# Altium schematic sheet Controller R2.SchDoc("Controller R2")
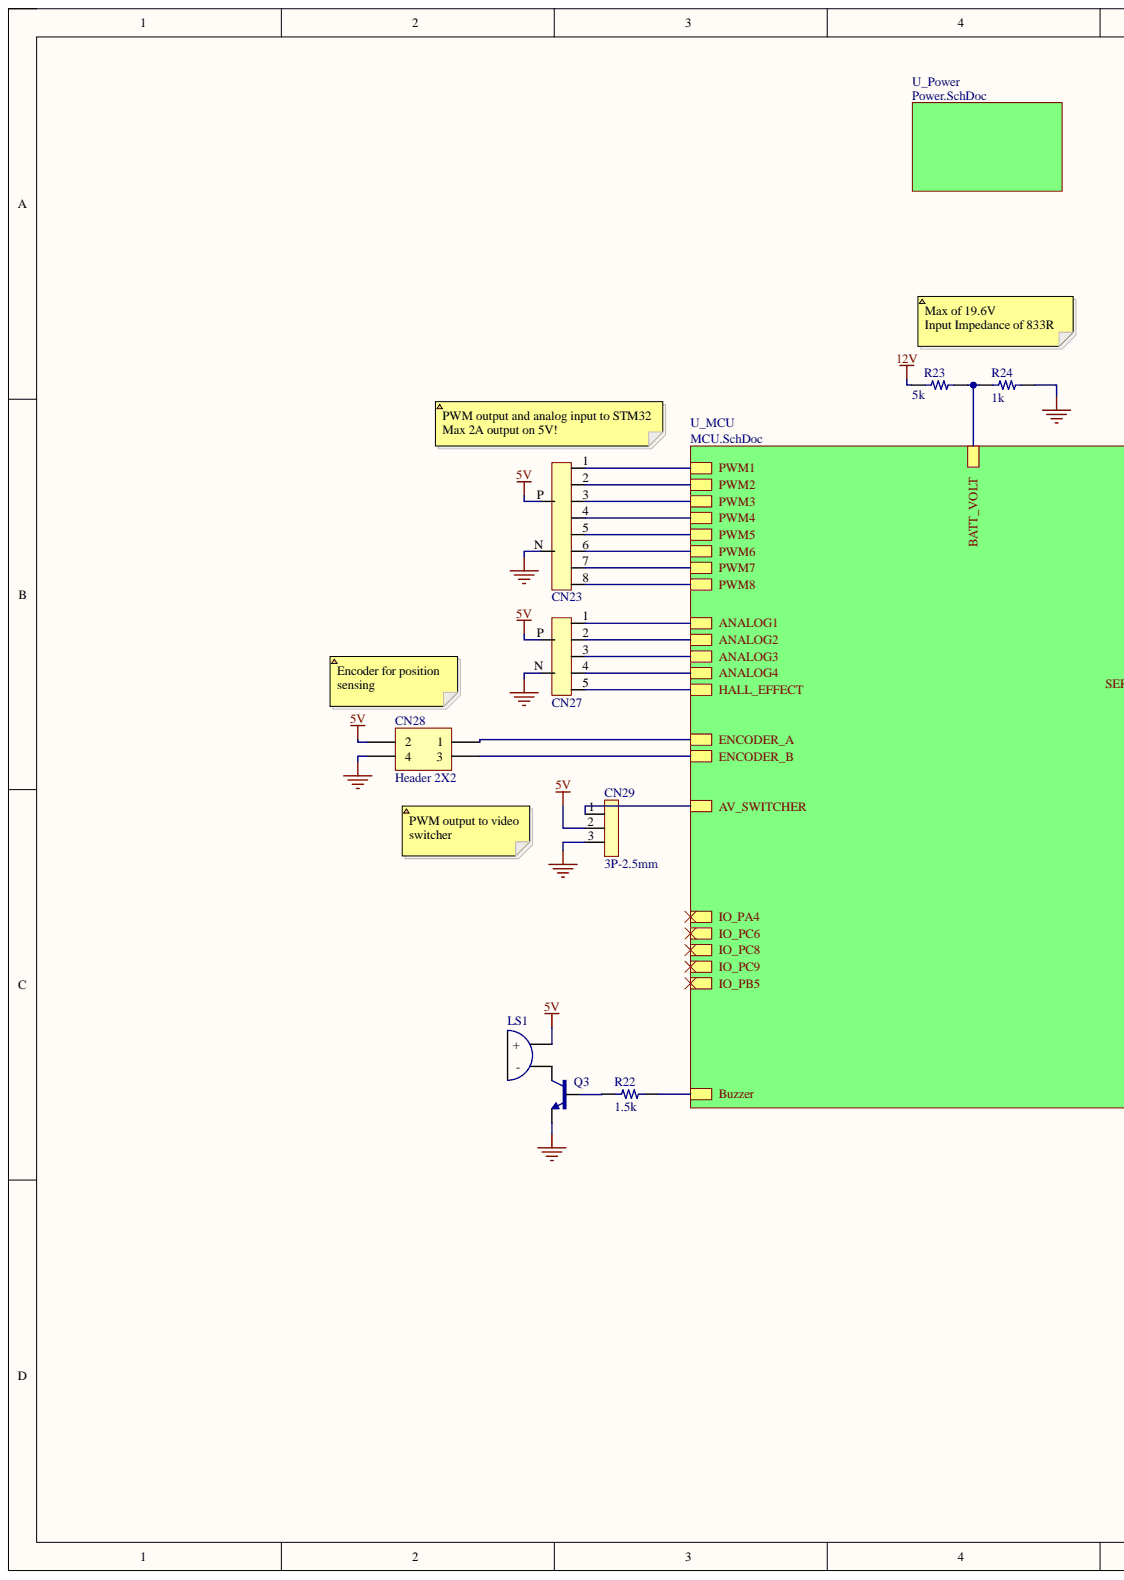
[diagram p0: Controller R2.SchDoc, left]
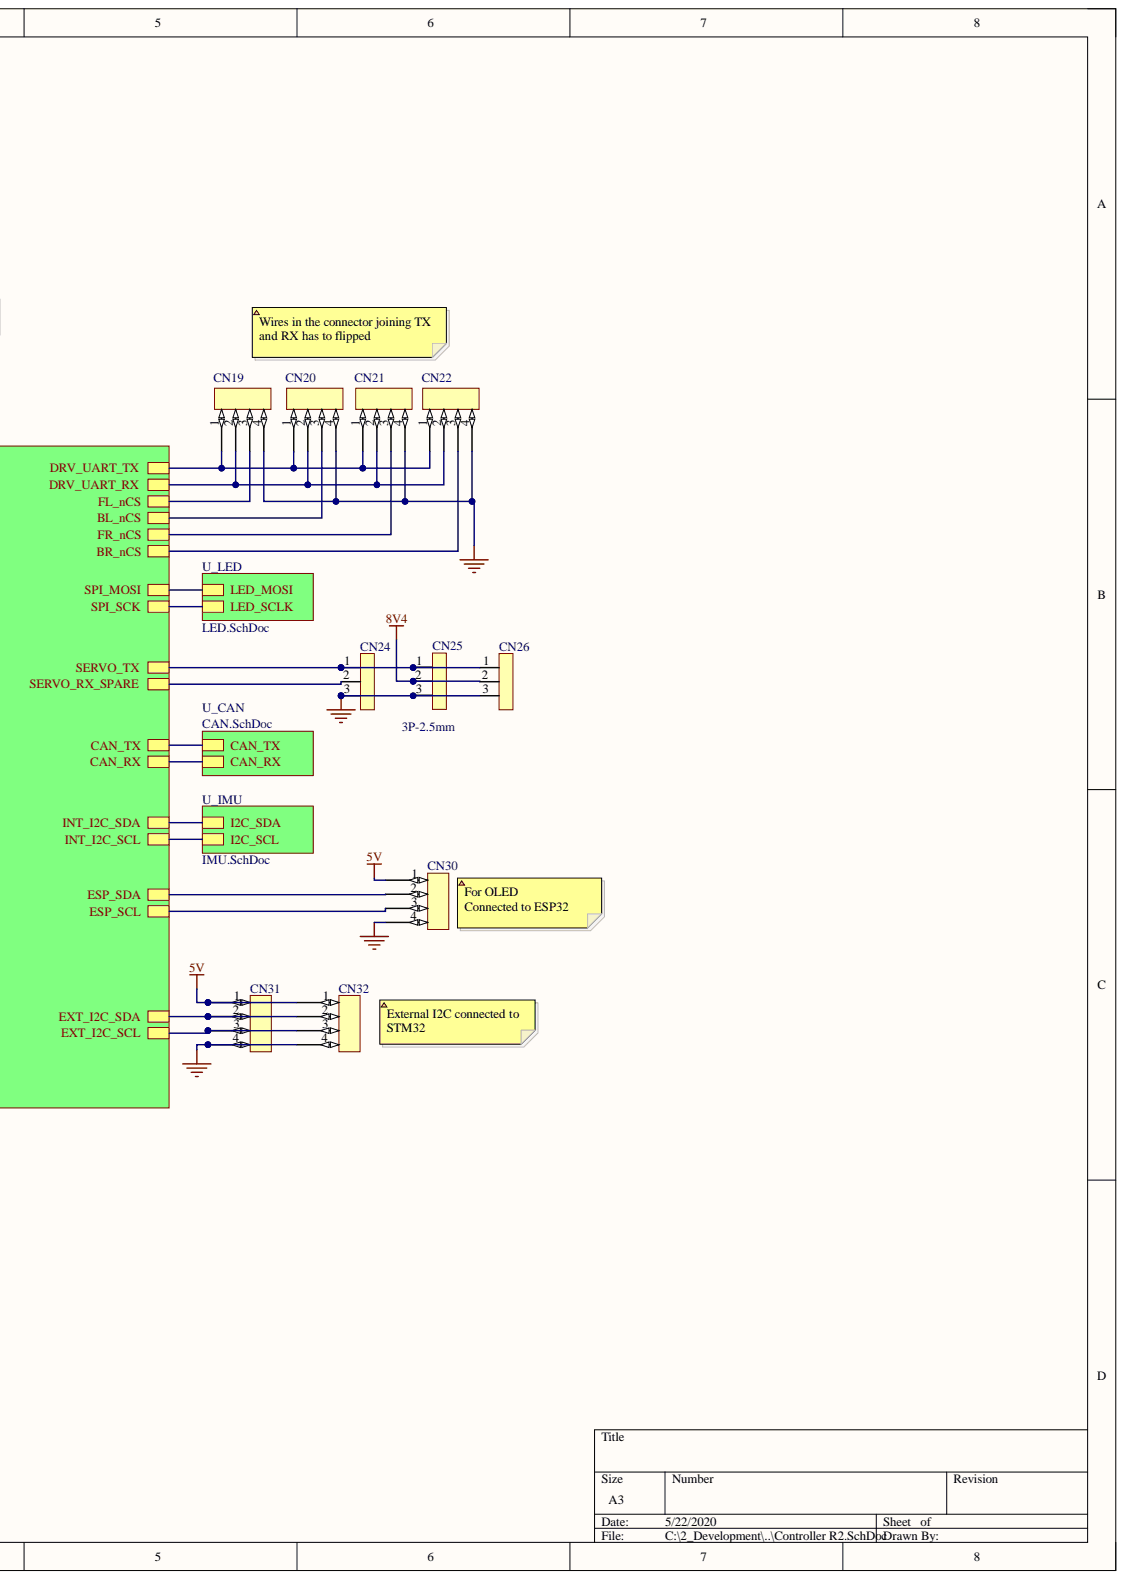
[diagram p1: Controller R2.SchDoc, right]

## Parts
CN19  JST_GH-4P  [JST_GH-4P]  (value not drawn)
CN20  JST_GH-4P  [JST_GH-4P]  (value not drawn)
CN21  JST_GH-4P  [JST_GH-4P]  (value not drawn)
CN22  JST_GH-4P  [JST_GH-4P]  (value not drawn)
CN23  8P-Signal-2.5mm  [8ch-signal-header]  (value not drawn)
CN24  3P-2.5mm  [2.5mm-3P]
CN25  3P-2.5mm  [2.5mm-3P]
CN26  3P-2.5mm  [2.5mm-3P]
CN27  5P-Signal-2.5mm  [5ch-signal-header]  (value not drawn)
CN28  Header 2X2  [HDR2X2]
CN29  3P-2.5mm  [2.5mm-3P]
CN30  JST_GH-4P  [JST_GH-4P]  (value not drawn)
CN31  JST_GH-4P  [JST_GH-4P]  (value not drawn)
CN32  JST_GH-4P  [JST_GH-4P]  (value not drawn)
LS1  Buzzer  [12-dia-buzzer]  (value not drawn)
Q3  S8050  [SOT23-FET]  (value not drawn)
R22  1.5k  [0805 - duplicate]
R23  5k  [0805 - duplicate]
R24  1k  [0805 - duplicate]

## Nets
5V: CN23.P CN27.P CN28.2 CN29.2 CN30.1 CN31.1 CN32.1 LS1.A
8V4: CN25.2 CN26.2
12V: R23.1
GND: CN19.4 CN20.4 CN21.4 CN22.4 CN23.N CN24.3 CN25.3 CN26.3 CN27.N CN28.4 CN29.3 CN30.4 CN31.4 CN32.4 Q3.2 R24.2
NetCN19_1: CN19.1 CN20.1 CN21.1 CN22.1
NetCN19_2: CN19.2 CN20.2 CN21.2 CN22.2
NetCN19_3: CN19.3
NetCN20_3: CN20.3
NetCN21_3: CN21.3
NetCN22_3: CN22.3
NetCN23_1: CN23.1
NetCN23_2: CN23.2
NetCN23_3: CN23.3
NetCN23_4: CN23.4
NetCN23_5: CN23.5
NetCN23_6: CN23.6
NetCN23_7: CN23.7
NetCN23_8: CN23.8
NetCN24_1: CN24.1 CN25.1 CN26.1
NetCN24_2: CN24.2
NetCN27_1: CN27.1
NetCN27_2: CN27.2
NetCN27_3: CN27.3
NetCN27_4: CN27.4
NetCN27_5: CN27.5
NetCN28_1: CN28.1
NetCN28_3: CN28.3
NetCN29_1: CN29.1
NetCN30_2: CN30.2
NetCN30_3: CN30.3
NetCN31_2: CN31.2 CN32.2
NetCN31_3: CN31.3 CN32.3
NetLS1_K: LS1.K Q3.3
NetQ3_1: Q3.1 R22.1
NetR22_2: R22.2
NetR23_2: R23.2 R24.1
CAN_RX:
CAN_TX:
I2C_SCL:
I2C_SDA:
IO_PA4:
IO_PB5:
IO_PC6:
IO_PC8:
IO_PC9:
LED_MOSI:
LED_SCLK:

## Library data of the parts
CN28 = Header 2X2: Header, 2-Pin, Dual row; Component Kind: Standard; LatestRevisionDate: 17-Jul-2002; LatestRevisionNote: Re-released for DXP Platform.; Library Name: Miscellaneous Connectors.IntLib; Signal Integrity: Connector; Publisher: Altium Limited
Q3 = S8050: MF: MICROSS/On Semiconductor; MP: 2N2222; Component Kind: Standard; Digi-Key_Part_Number: 1086-15156-ND; Digi-Key_Purchase_URL: https://www.digikey.com.mx/product-detail/en/microsemi-corporation/JANS2N2222A/1086-15156-ND/4384334?utm_source=snapeda&utm_medium=aggregator&utm_campaign=symbol; Library Name: Parts.SchLib; Package: TO-18-3 NJS; SnapEDA_Link: https://www.snapeda.com/parts/2N2222/MICROSS/On%20Semiconductor/view-part/561967/?ref=snap
R22-R24 = Resistor: Component Kind: Standard; Library Name: Parts.SchLib
CN19-CN22 CN30-CN32 = JST_GH-4P: Component Kind: Standard; Library Name: Parts.SchLib
CN23 = 8P-Signal-2.5mm: Component Kind: Standard; Library Name: Parts.SchLib
CN24-CN26 CN29 = 3P-2.5mm: Component Kind: Standard; Library Name: Parts.SchLib
CN27 = 5P-Signal-2.5mm: Component Kind: Standard; Library Name: Parts.SchLib
LS1 = Buzzer: Component Kind: Standard; Library Name: Parts.SchLib
